# Altium schematic sheet main.SchDoc(main)
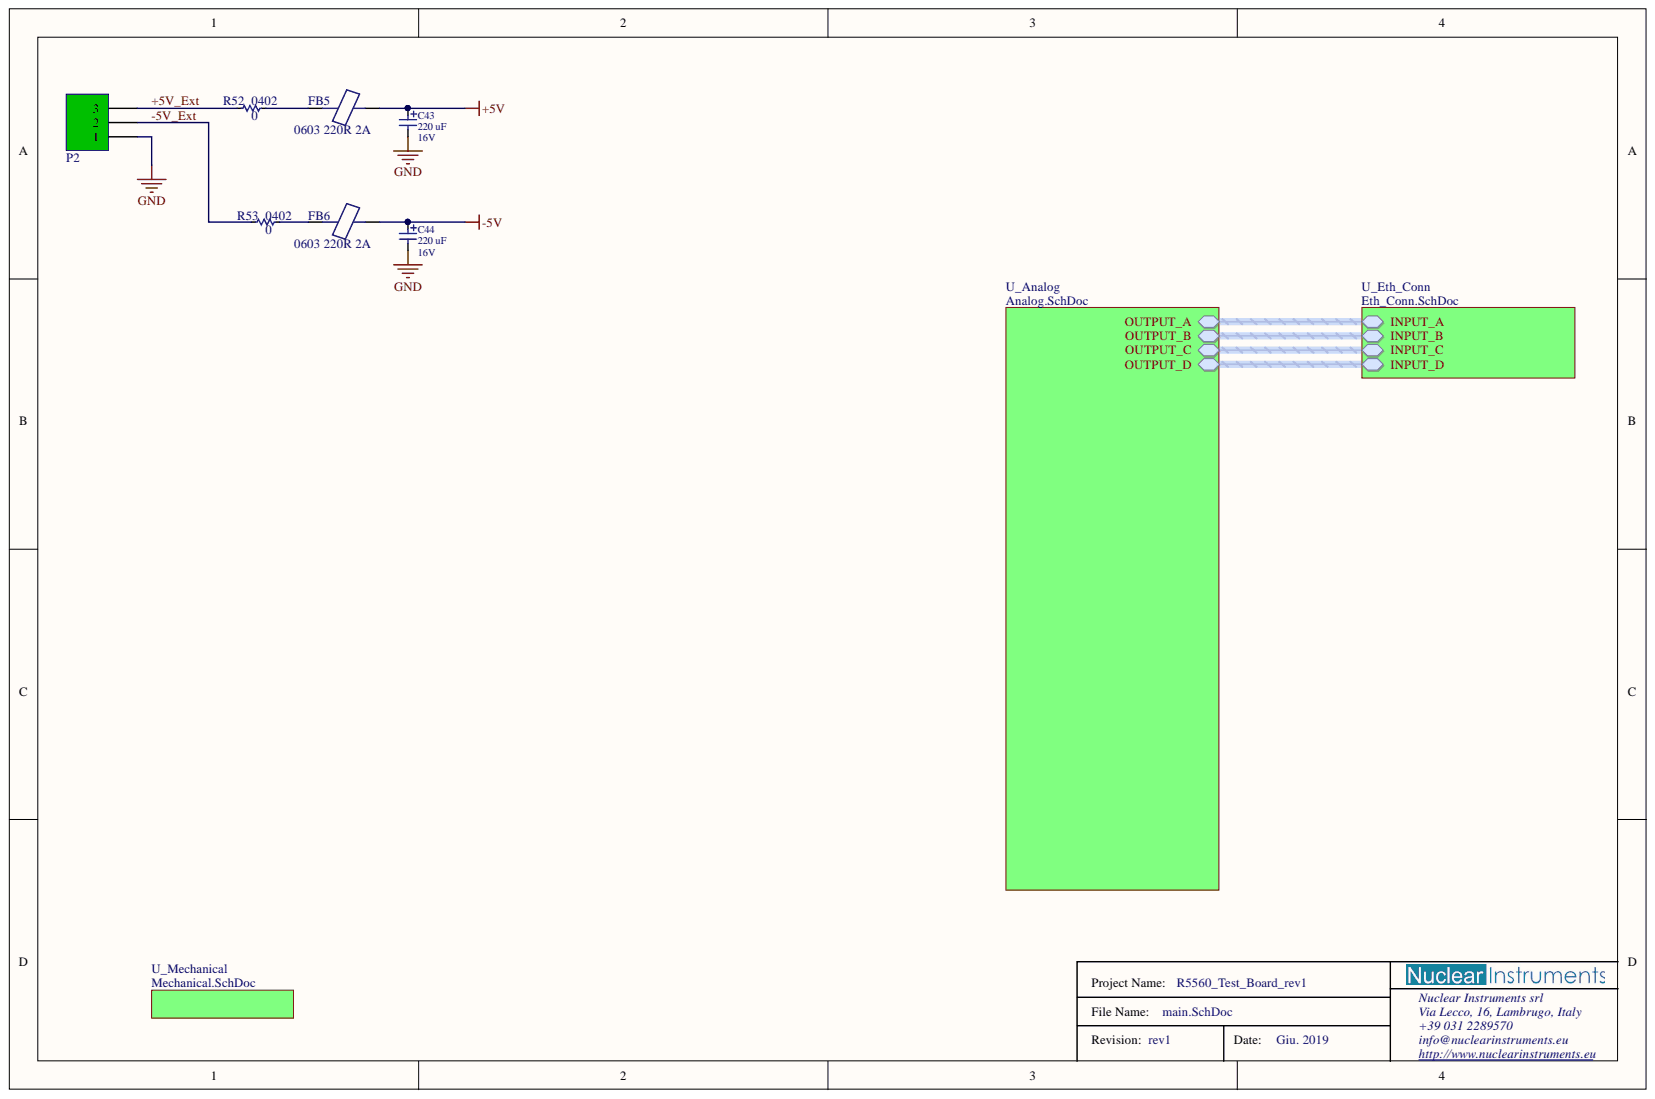
[diagram p0: main.SchDoc]

## Parts
C43  value 220 uF  Voltage: 16V  [CAPELECTSMD_L83_H62]  (hidden comment: CAPELECT)
C44  value 220 uF  Voltage: 16V  [CAPELECTSMD_L83_H62]  (hidden comment: CAPELECT)
FB5  0603 220R 2A  value 220  [FERSMD0603]
FB6  0603 220R 2A  value 220  [FERSMD0603]
P2  3 way 5.08mm pitch  [Wurth_2135_3way_5.08mm_screw]  (value not drawn)
R52  0402  value 0  [RESSMD0402]
R53  0402  value 0  [RESSMD0402]

## Nets
+5V: C43.1 FB5.2
-5V: C44.1 FB6.2
GND: C43.2 C44.2 P2.1
+5V_Ext: P2.3 R52.1
-5V_Ext: P2.2 R53.1
NetFB5_1: FB5.1 R52.2
NetFB6_1: FB6.1 R53.2
OUTPUT_A.1_N:
OUTPUT_A.1_P:
OUTPUT_A.2_N:
OUTPUT_A.2_P:
OUTPUT_A.3_N:
OUTPUT_A.3_P:
OUTPUT_A.4_N:
OUTPUT_A.4_P:
OUTPUT_A.5_N:
OUTPUT_A.5_P:
OUTPUT_A.6_N:
OUTPUT_A.6_P:
OUTPUT_A.7_N:
OUTPUT_A.7_P:
OUTPUT_A.8_N:
OUTPUT_A.8_P:
OUTPUT_B.1_N:
OUTPUT_B.1_P:
OUTPUT_B.2_N:
OUTPUT_B.2_P:
OUTPUT_B.3_N:
OUTPUT_B.3_P:
OUTPUT_B.4_N:
OUTPUT_B.4_P:
OUTPUT_B.5_N:
OUTPUT_B.5_P:
OUTPUT_B.6_N:
OUTPUT_B.6_P:
OUTPUT_B.7_N:
OUTPUT_B.7_P:
OUTPUT_B.8_N:
OUTPUT_B.8_P:
OUTPUT_C.1_N:
OUTPUT_C.1_P:
OUTPUT_C.2_N:
OUTPUT_C.2_P:
OUTPUT_C.3_N:
OUTPUT_C.3_P:
OUTPUT_C.4_N:
OUTPUT_C.4_P:
OUTPUT_C.5_N:
OUTPUT_C.5_P:
OUTPUT_C.6_N:
OUTPUT_C.6_P:
OUTPUT_C.7_N:
OUTPUT_C.7_P:
OUTPUT_C.8_N:
OUTPUT_C.8_P:
OUTPUT_D.1_N:
OUTPUT_D.1_P:
OUTPUT_D.2_N:
OUTPUT_D.2_P:
OUTPUT_D.3_N:
OUTPUT_D.3_P:
OUTPUT_D.4_N:
OUTPUT_D.4_P:
OUTPUT_D.5_N:
OUTPUT_D.5_P:
OUTPUT_D.6_N:
OUTPUT_D.6_P:
OUTPUT_D.7_N:
OUTPUT_D.7_P:
OUTPUT_D.8_N:
OUTPUT_D.8_P:

## Library data of the parts
C43 C44 = CAPELECT_PANASONIC_L83_H62_FK_16V_220u: Cond. Elettrolitico Allum.220 µF, 16 V; NICode: 00660000026; NIClass: Standard; Component Kind: Standard; Library Name: Cap_pol__SARAversion-9.schlib
FB5 FB6 = FER0603_BLM18EG221SN1D_220R: Value: 220; NICode: 00330000195; NIClass: Standard; Component Kind: Standard; Library Name: Ferrite_Beads__SARAversion-10.schlib
P2 = Wurth_2135_3way_5.08mm_screw: Serie 2135- 5.08mm Horizontal Entry Modular w. Rising Cage Clamp WR-TBL; NICode: 00280001645; NIClass: Standard; Component Kind: Standard; Library Name: Board_Wire_Connectors__SARAversion-93.schlib
R52 R53 = RES0402-0R: NICode: 00300000186; NIClass: Standard; Component Kind: Standard; Library Name: 0402_Resistors__SARAversion-52.schlib
